# Altium schematic sheet mcu.SchDoc(mcu)
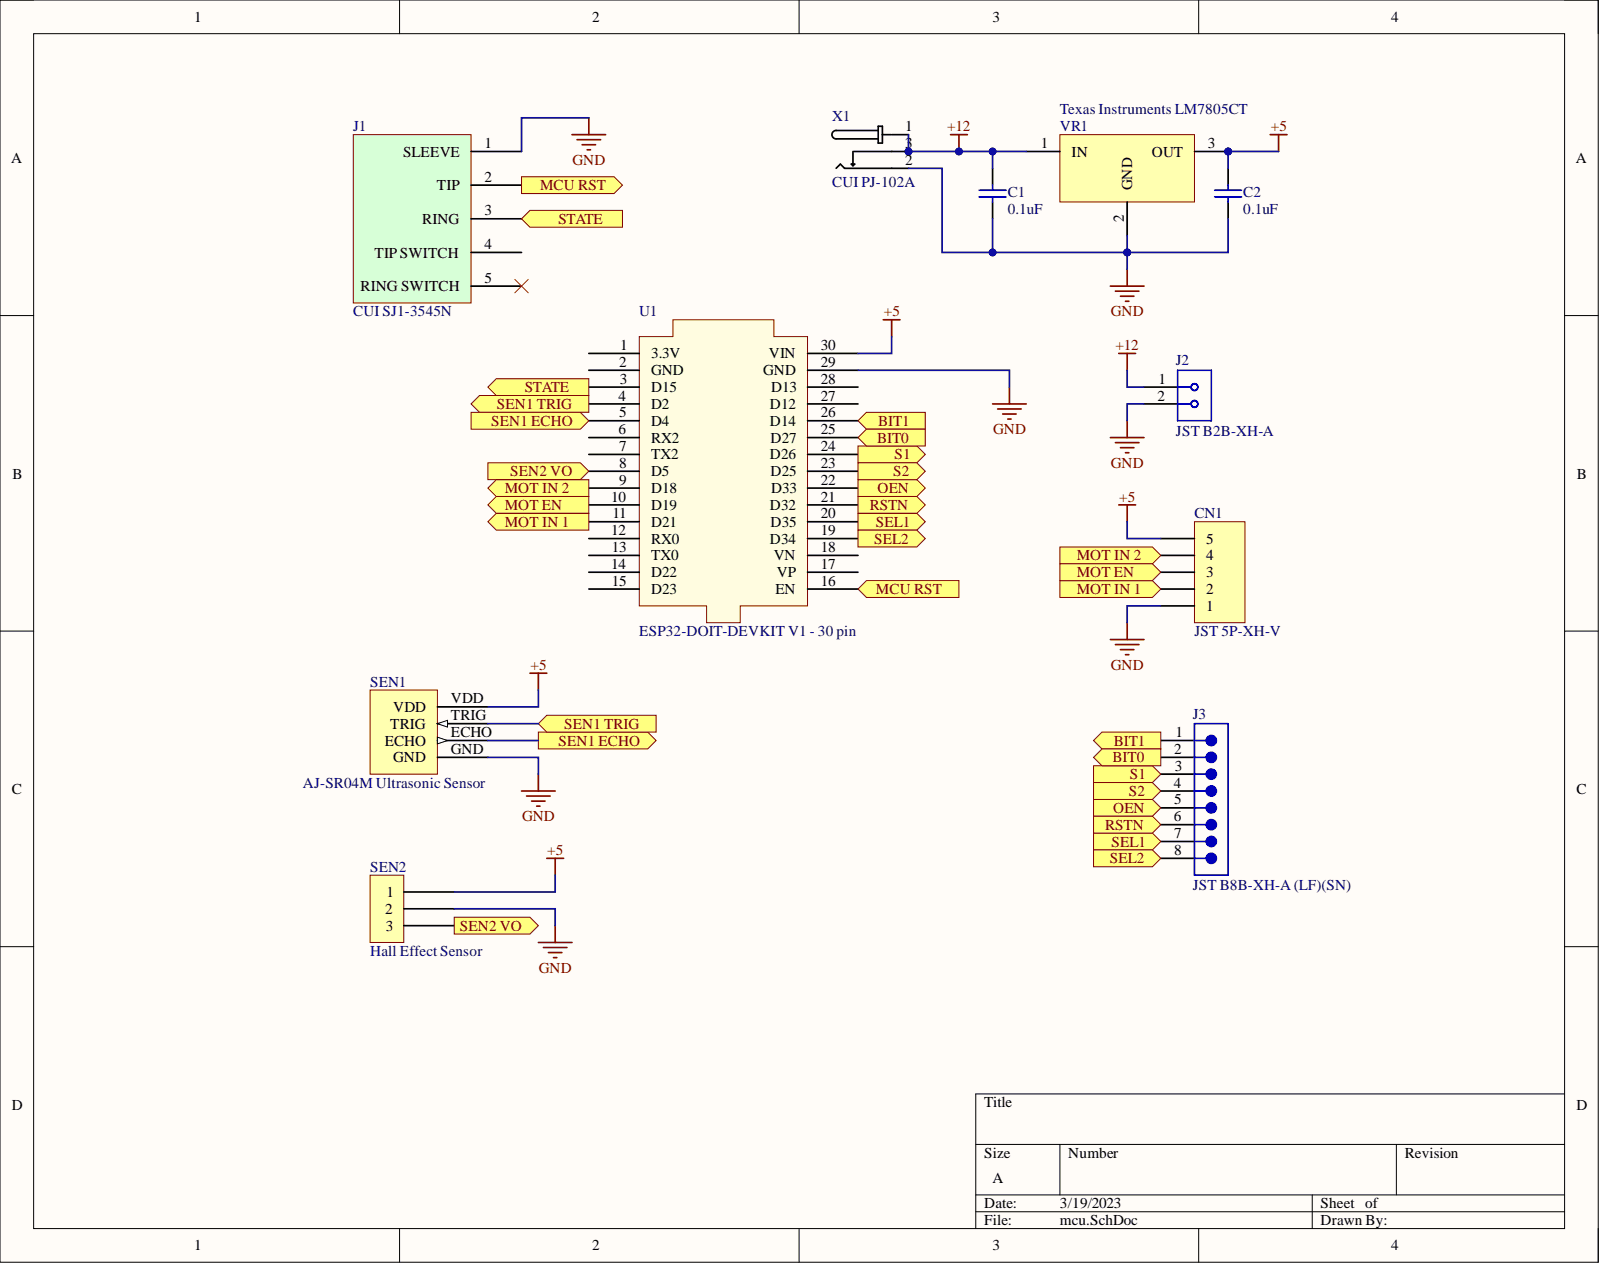
[diagram p0: mcu.SchDoc]

## Parts
C1  0.1uF
C2  0.1uF
CN1  JST 5P-XH-V  [PCB-mfi6vvq65x2nl6te1krx-1]
J1  CUI SJ1-3545N
J2  JST B2B-XH-A  Manufacturer Part Number: B2B-XH-A  [PCB-pp1at3pfx7vls1ur72ey-1]
J3  JST B8B-XH-A (LF)(SN)  [PCB-b845vrqo10dzr8zj63r3-1]
SEN1  AJ-SR04M Ultrasonic Sensor
SEN2  Hall Effect Sensor  [PCB-64rbjwan972qukdfc7yz-1]
U1  ESP32-DOIT-DEVKIT V1 - 30 pin  [PCB-isg9v9ll4hq1tfh79a57-1]
VR1  Texas Instruments LM7805CT  Manufacturer Part Number: LM7805CT  [PCB-j8hb0l7ph92hubhfpdkv-1]
X1  CUI PJ-102A  Manufacturer Part Number: PJ-102A  [PCB-nqvis3mp8bd6z4o4if5v-1]

## Ports
BIT0, BIT1, MCU RST, MOT EN, MOT IN 1, MOT IN 2, OEN, RSTN, S1, S2, SEL1, SEL2, SEN1 ECHO, SEN1 TRIG, SEN2 VO, STATE

## Nets
+5: C2.2 CN1.5 SEN1.VDD SEN2.1 U1.30(VIN) VR1.3
+12: C1.2 J2.1 VR1.1 X1.1 X1.3
GND: C1.1 C2.1 CN1.1 J1.1(SLEEVE) J2.2 SEN1.GND SEN2.2 U1.29(GND) VR1.2 X1.2
BIT0: J3.2 U1.25(D27) ; port BIT0
BIT1: J3.1 U1.26(D14) ; port BIT1
MCU RST: J1.2(TIP) U1.16(EN) ; port MCU RST
MOT EN: CN1.3 U1.10(D19) ; port MOT EN
MOT IN 1: CN1.2 U1.11(D21) ; port MOT IN 1
MOT IN 2: CN1.4 U1.9(D18) ; port MOT IN 2
OEN: J3.5 U1.22(D33) ; port OEN
RSTN: J3.6 U1.21(D32) ; port RSTN
S1: J3.3 U1.24(D26) ; port S1
S2: J3.4 U1.23(D25) ; port S2
SEL1: J3.7 U1.20(D35) ; port SEL1
SEL2: J3.8 U1.19(D34) ; port SEL2
SEN1 ECHO: SEN1.ECHO U1.5(D4) ; port SEN1 ECHO
SEN1 TRIG: SEN1.TRIG U1.4(D2) ; port SEN1 TRIG
SEN2 VO: SEN2.3 U1.8(D5) ; port SEN2 VO
STATE: J1.3(RING) U1.3(D15) ; port STATE

Not connected: J1 4(TIP_SWITCH) 5(RING_SWITCH); U1 1(3.3V) 2(GND) 6(RX2) 7(TX2) 12(RX0) 13(TX0) 14(D22) 15(D23) 17(VP) 18(VN) 27(D12) 28(D13)

## Library data of the parts
C1 C2 = CMP-128540-5: CAP CER 0.1UF 50V 10% RADIAL; Manufacturer Part Number: K104K10X7RF5UH5; Capacitance: 0.1u; Dielectric Characteristic: X7R; Voltage Rating (DC): 50.0 V; Capacitance Tolerance: ±10%; Component Kind: Standard; Library Name: My Personal Space; Octopart Url: https://octopart.com/k104k10x7rf5uh5-vishay-44036914; OctopartID: 39a8f23deffce66f; Size-Diameter: 0.0005 m; Size-Height: 0.0036 m; Size-Width: 0.0036 m
CN1 = CMP-2481793-9: Connector Header, XH Series, 5 Position, 2.50mm, Through Hole, Press-Fit, Solder, Natural; Manufacturer Part Number: B5B-XH-A(LF)(SN); Contact Resistance: 10.0 mΩ; Current Rating: 3.00 A; Gender: Male; Insulation Resistance: 1.00 GΩ; Lead-Free Status: Lead Free; Number of Contacts: 5; Voltage Rating (AC): 250 V; Component Kind: Standard; Contact Material: Brass; Contact Plating: Tin, Tin Lead; Library Name: My Personal Space; Mounting Style: Through Hole, Vertical; Octopart URL: https://octopart.com/b5b-xh-a%28lf%29%28sn%29-jst-3990208; OctopartID: 8031490747975ff6; Operating Temperature: -25.0 °C (min); Packaging: Box; REACH SVHC Compliance: No SVHC; RoHS: Compliant
J1 = CMP-ae086701e1e671cf-3: 3.5 mm Right-Angle Stereo Jack, 5 Pin PCB Mount, Non-Threaded; Component Kind: Standard; Library Name: My Personal Space
J2 = CMP-1d67d451da9ec2a0-5: Conn Shrouded Header HDR 2 POS 2.5mm Solder ST Thru-Hole Box; Component Kind: Standard; Contact Material: Phosphor Bronze; Library Name: My Personal Space; Octopart URL: https://octopart.com/b2b-xh-a-jst-3990175; OctopartID: 1d67d451da9ec2a0; Orientation: Straight
J3 = CMP-da9f13e788db0558-6: XH Series 6 Position 2.5 mm Pitch Through Hole Top Entry Shrouded Header; Component Kind: Standard; Library Name: My Personal Space
SEN1 = CMP-75f1bf27582daa36-16: Ultrasonic Sensor Module, Rectangular, 3-5 V,  Arduino Compatible, Posed Horizontally; Component Kind: Standard; Library Name: My Personal Space
SEN2 = CMP-121adb8ffbbdcddd-2: Conn; Rect; Header; 3 Cir; PCB; MicroFit 3.0; Rt Angle; Single; SMT; w/Tab; Tin; Manufacturer Part Number: 43650-0312; Current Rating: 5.00 A; Gender: Male; Glow Wire Compliant: Compliant; Lead-Free Status: Contains Lead; Mated Height: 6.98 mm; Number of Circuits: 3; Pin Pitch: 3.00 mm; Voltage Rating (DC): 250 V; Color: Black; Component Kind: Standard; Contact Material: Brass; Contact Plating: Tin; Flammability Rating: UL 94 V-0; Halogen Free Status: Halogen Free; Housing Color: Black; Housing Material: Plastic; Library Name: My Personal Space; Lifecycle Status: Active; Mounting Style: Horizontal, Surface Mount, PCB; Number of Contacts: 3; Number of Positions: 3; Number of Rows: 1; Octopart URL: https://octopart.com/43650-0312-molex-298103; OctopartID: 91c658c838d74e01; Operating Temperature: 105 °C (max); Orientation: Right Angle; Packaging: Tray; RoHS: Compliant; Size-Height: 4.37 mm; Size-Length: 12.6 mm; Size-Width: 9.90 mm
U1 = CMP-cc93003941751354-7: Manufacturer Part Number: ESP32-DEVKITC-VIB; Component Kind: Standard; Description: ESP32-DOIT-DEVKIT V1 - 30 pin; Library Name: My Personal Space; Octopart URL: https://octopart.com/esp32-devkitc-vib-espressif+systems-94414976; OctopartID: cc93003941751354
VR1 = CMP-2633ef4966bbf9f4-4: LM78XX Series 5 V Fixed Output 1 A 3-Terminal LDO Voltage Regulator - TO-220; Current Rating: 1.00 A; Dropout Voltage: 2.00 V; Input Voltage (DC): 35.0 V (max); Lead-Free Status: Lead Free; Number of Outputs: 1; Output Capacitor Type: Ceramic; Output Voltage: 5.20 V, 5.00 V; Quiescent Current: 8.00 mA; Case/Package: TO-220; Component Kind: Standard; Library Name: My Personal Space; Lifecycle Status: Active; Mounting Style: Through Hole; Number of Pins: 3; Number of Regulated Outputs: 1; Octopart URL: http://octopart.com/lm7805ct-texas+instruments-7366126; OctopartID: 2633ef4966bbf9f4; Output Current: 1.00 A; Packaging: Tube; REACH SVHC Compliance: No SVHC; RoHS: Compliant
X1 = CMP-946b5d65d1d54bac-4: 2.0 x 6.5 mm, 2.5 A, Horizontal, Through Hole, Tapered Pins, Dc Power Jack Connector; Contact Resistance: 30.0 mΩ (max); Gender: Male; Insulation Resistance: 50.0 MΩ (min); Lead-Free Status: Lead Free; Mounting Angle: 90.0 °; Shielding: No; Color: Black; Component Kind: Standard; Contact Plating: Nickel; Library Name: My Personal Space; Lifecycle Status: Active; Mounting Style: Through Hole; Octopart URL: https://octopart.com/pj-102a-cui-286936; OctopartID: 946b5d65d1d54bac; Orientation: Horizontal; Packaging: Bulk; RoHS: Compliant; Size-Diameter: 2.10 mm
